# Altium schematic sheet 电源.SchDoc(电源)
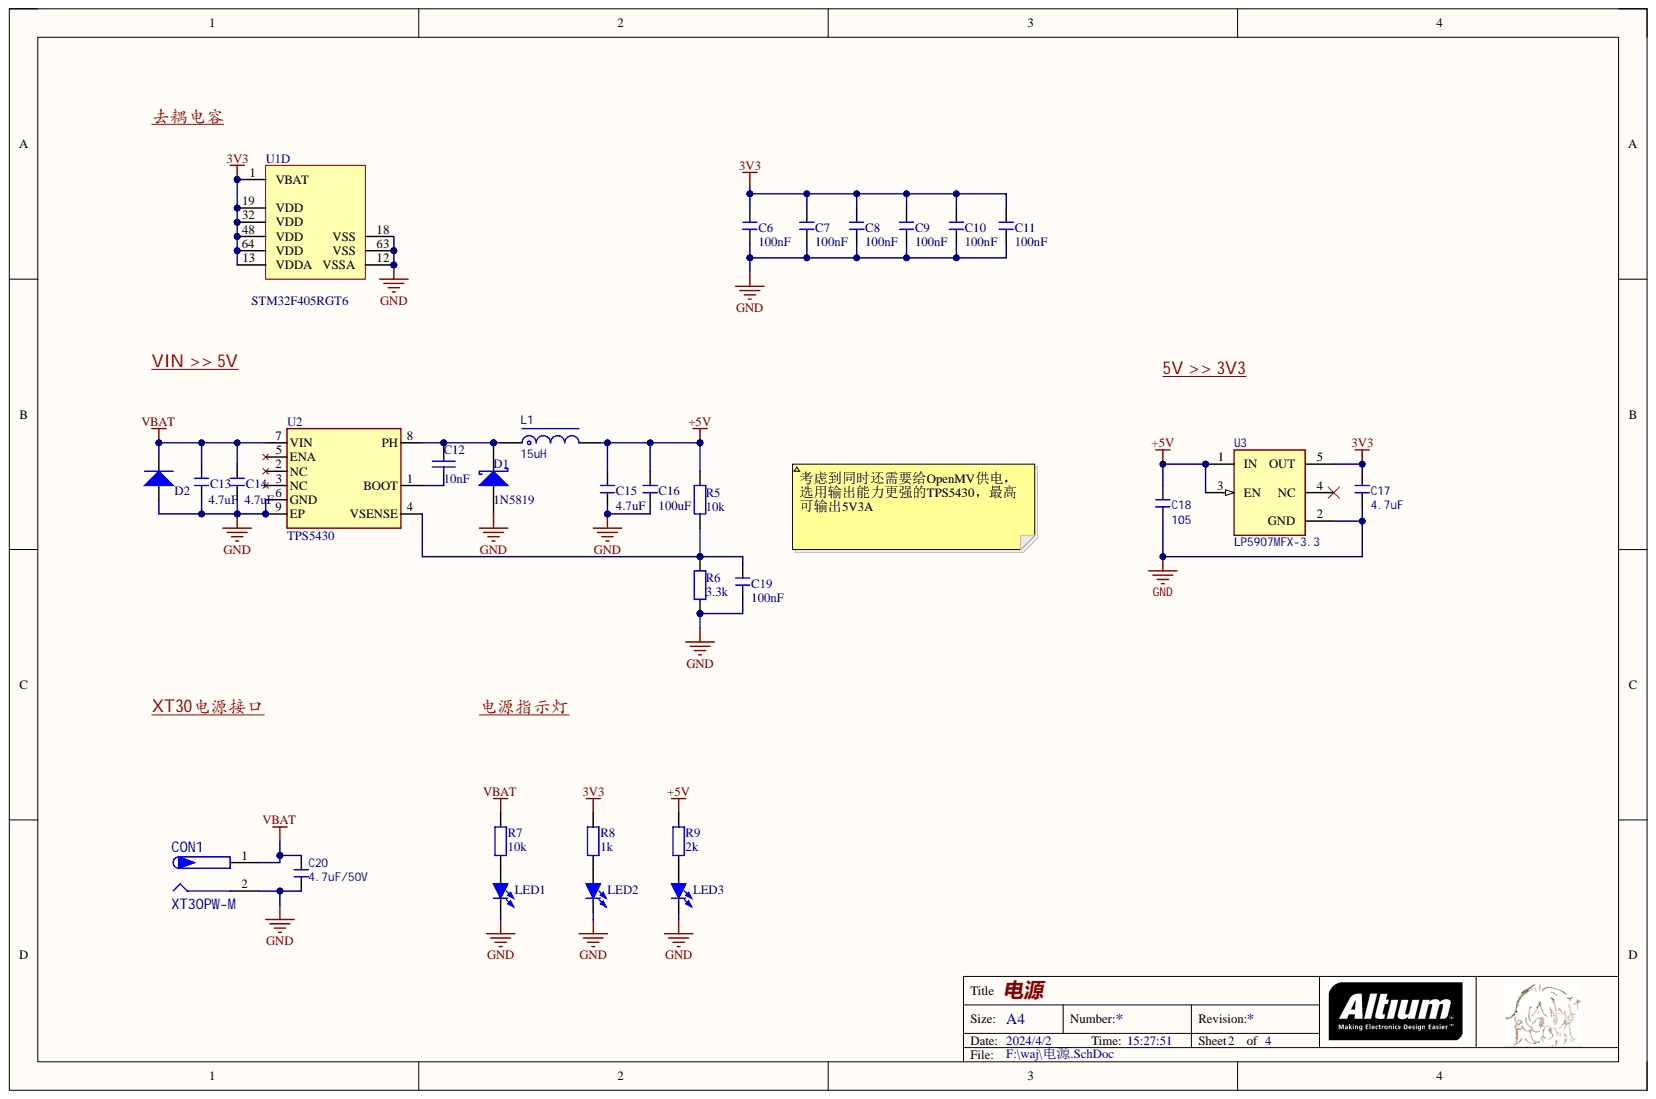
[diagram p0: 电源.SchDoc]

## Parts
C6  100nF  [C 0603_L]
C7  100nF  [C 0603_L]
C8  100nF  [C 0603_L]
C9  100nF  [C 0603_L]
C10  100nF  [C 0603_L]
C11  100nF  [C 0603_L]
C12  10nF  [C 0603_M]
C13  4.7uF  [C 1210_L]
C14  4.7uF  [C 1210_L]
C15  4.7uF  [C 1210_L]
C16  100uF  [C 1210_L]
C17  4.7uF  [C 0603_L]
C18  105  [C 0603_L]
C19  100nF  [C 0603_L]
C20  4.7uF/50V  [C 1210_L]
CON1  XT30PW-M  [XT30PW-M]
D1  1N5819  [SMA]
D2  TVS  [DIODE-SMA]  (value not drawn)
L1  15uH  [NR4030]
LED1  红色  [LED 0603W]  (value not drawn)
LED2  红色  [LED 0603W]  (value not drawn)
LED3  红色  [LED 0603W]  (value not drawn)
R5  10k  [R 0603_M]
R6  3.3k  [R 0603_M]
R7  10k  [R 0603_L]
R8  1k  [R 0603_L]
R9  2k  [R 0603_L]
U1  STM32F405RGT6  [LQFP64 10x10_M]  (part D)
U2  TPS5430  [SOIC-8-EP]
U3  LP5907MFX-3.3  [Diodes_SOT25_MFG]

## Nets
3V3: C6.2 C7.2 C8.2 C9.2 C10.2 C11.2 C17.2 R8.2 U1.1 U1.13 U1.19 U1.32 U1.48 U1.64 U3.5
+5V: C15.2 C16.2 C18.2 L1.2 R5.1 R9.2 U3.1 U3.3
GND: C6.1 C7.1 C8.1 C9.1 C10.1 C11.1 C13.1 C14.1 C15.1 C16.1 C17.1 C18.1 C19.1 C20.1 CON1.2 D1.1 D2.1 LED1.2 LED2.2 LED3.2 R6.2 U1.12 U1.18 U1.63 U2.6 U2.9 U3.2
VBAT: C13.2 C14.2 C20.2 CON1.1 D2.2 R7.2 U2.7
NetC12_1: C12.1 U2.1
NetC12_2: C12.2 D1.2 L1.1 U2.8
NetC19_2: C19.2 R5.2 R6.1 U2.4
NetLED1_1: LED1.1 R7.1
NetLED2_1: LED2.1 R8.1
NetLED3_1: LED3.1 R9.1

Not connected: U2 2 3 5; U3 4

## Library data of the parts
C6-C11 C13-C16 C19 = C: 贴片电容; BOM名称: 无极性贴片电容; 淘宝ID: 封装库来自淘宝 ID：黄晶宝石; Component Kind: Standard; Library Name: 0.1 - 电阻-电容-电感.SchLib; 唯一出售店铺网址: https://shop463601444.taobao.com; 联系售后，技术支持: https://shop463601444.taobao.com
C12 = Cap: Capacitor; Component Kind: Standard; Library Name: Miscellaneous Devices.IntLib; Signal Integrity: Cap; Simulation: CAP
C17 C18 C20 = C: 无极性贴片电容; 材质: X7R; BOM名称: 无极性贴片电容; Component Kind: Standard; Library Name: 3.1   - 电阻-电容-电感.SchLib
CON1 = XT30PW-M: XT30PW-M PCB板专用卧式连接器，低温升阻燃插头; Component Kind: Standard; Library Name: CMD_G474.SCHLIB
D1 = Diode 11DQ03: Schottky Rectifier; Component Kind: Standard; ComponentLink1Description: Manufacturer Link; ComponentLink1URL: http://www.irf.com/; ComponentLink2Description: Datasheet; ComponentLink2URL: http://www.irf.com/product-info/datasheets/data/11dq03.pdf; Library Name: Miscellaneous Devices.IntLib; Simulation: 11DQ03
D2 = TVS: TVS保护; Type: E6.8CA; Code_JEDEC: DO-214-AB; Component Kind: Standard; LatestRevisionDate: 17-Jul-2002; LatestRevisionNote: Re-released for DXP Platform.; Library Name: 0.2 - 二极管-整流桥.SchLib; PackageDocument: Sep-1996; PackageReference: SMC; Published: 8-Jun-2000; Publisher: Altium Limited
L1 = L-NR: NR磁胶电感; BOM名称: NR磁胶电感; Component Kind: Standard; Library Name: 钝角.SCHLIB
LED1-LED3 = LED-SMD: 贴片LED; 版权归属: 封装库来自淘宝 ID：黄晶宝石; BOM名称: 贴片LED; Component Kind: Standard; Library Name: 0.3 - LED发光二极管.SchLib; 学习资源: https://shop463601444.taobao.com
R5 R6 = Res2: Resistor; Component Kind: Standard; Library Name: Miscellaneous Devices.IntLib; Signal Integrity: Res; Simulation: RESISTOR
R7-R9 = R: 贴片电阻; 封装库来自淘宝卖家 ID：黄晶宝石: 其他店铺购入均为盗版，不享受售后技术支持服务，请立即申请退款; 版权归属: 来自淘宝 ID：黄晶宝石; BOM名称: 贴片电阻; Component Kind: Standard; Library Name: 0.1 - 电阻-电容-电感.SchLib; 联系售后: https://shop463601444.taobao.com
U1 = STM32F405RGT6: ARM Cortex-M4 32b MCU+FPU, 210DMIP, 1024 kB Flash, 192+4 kB RAM, 51 I/Os, 64-pin LQFP, -40 to +85癈, Tray; 16-bit Timers (IC/OC/PWM): 12x16-bit(24/24/30); 32-bit Timer (IC/OC/PWM): 2x32-bit (8/8/8); A/D Converter: 16x12-bit; D/A Converter: 2x12-bit; Internal RAM: 192kB; Operating Frequency (Processor Speed): 168MHz; Other Timer Functions: 2xWDG, RTC, 24-bit down counter; Serial Interface: 3xSPI/2xI2S/2xI2C/3xUSART(IrDa, ISO7816)/3xUART/1xUSB OTG FS/HS, 2xCAN/ SDIO; Component Kind: Standard; ComponentLink1Description: Manufacturer URL; ComponentLink1URL: http://www.st.com/; ComponentLink2Description: STM32F405/7 Datasheet; ComponentLink2URL: http://www.st.com/internet/com/TECHNICAL_RESOURCES/TECHNICAL_LITERATURE/DATASHEET/DM00037051.pdf; ComponentLink3Description: STM32F4 Web Page; ComponentLink3URL: http://www.st.com/internet/mcu/subclass/1521.jsp; DatasheetVersion: rev2, Jan-2012; Library Name: 2.5 - STM32 F4系列单片机.SchLib; PackageDescription: 64-Pin Low Profile Quad Flat Package, 10x10 mm Body, 0.5 mm Pitch; PackageReference: TQFP64; PackageVersion: 2012
U3 = LP5907MFX-3.3-NOPB: 250-mA ultra-low-noise low-IQ low-dropout (LDO) linear regulator 5-SOT-23 -40 to 125; Component Kind: Standard; Library Name: 钝角.SCHLIB
U2 = TPS5430: Component Kind: Standard; Library Name: my_library.SchLib
